# Altium schematic sheet 4_Sensing_I.SchDoc
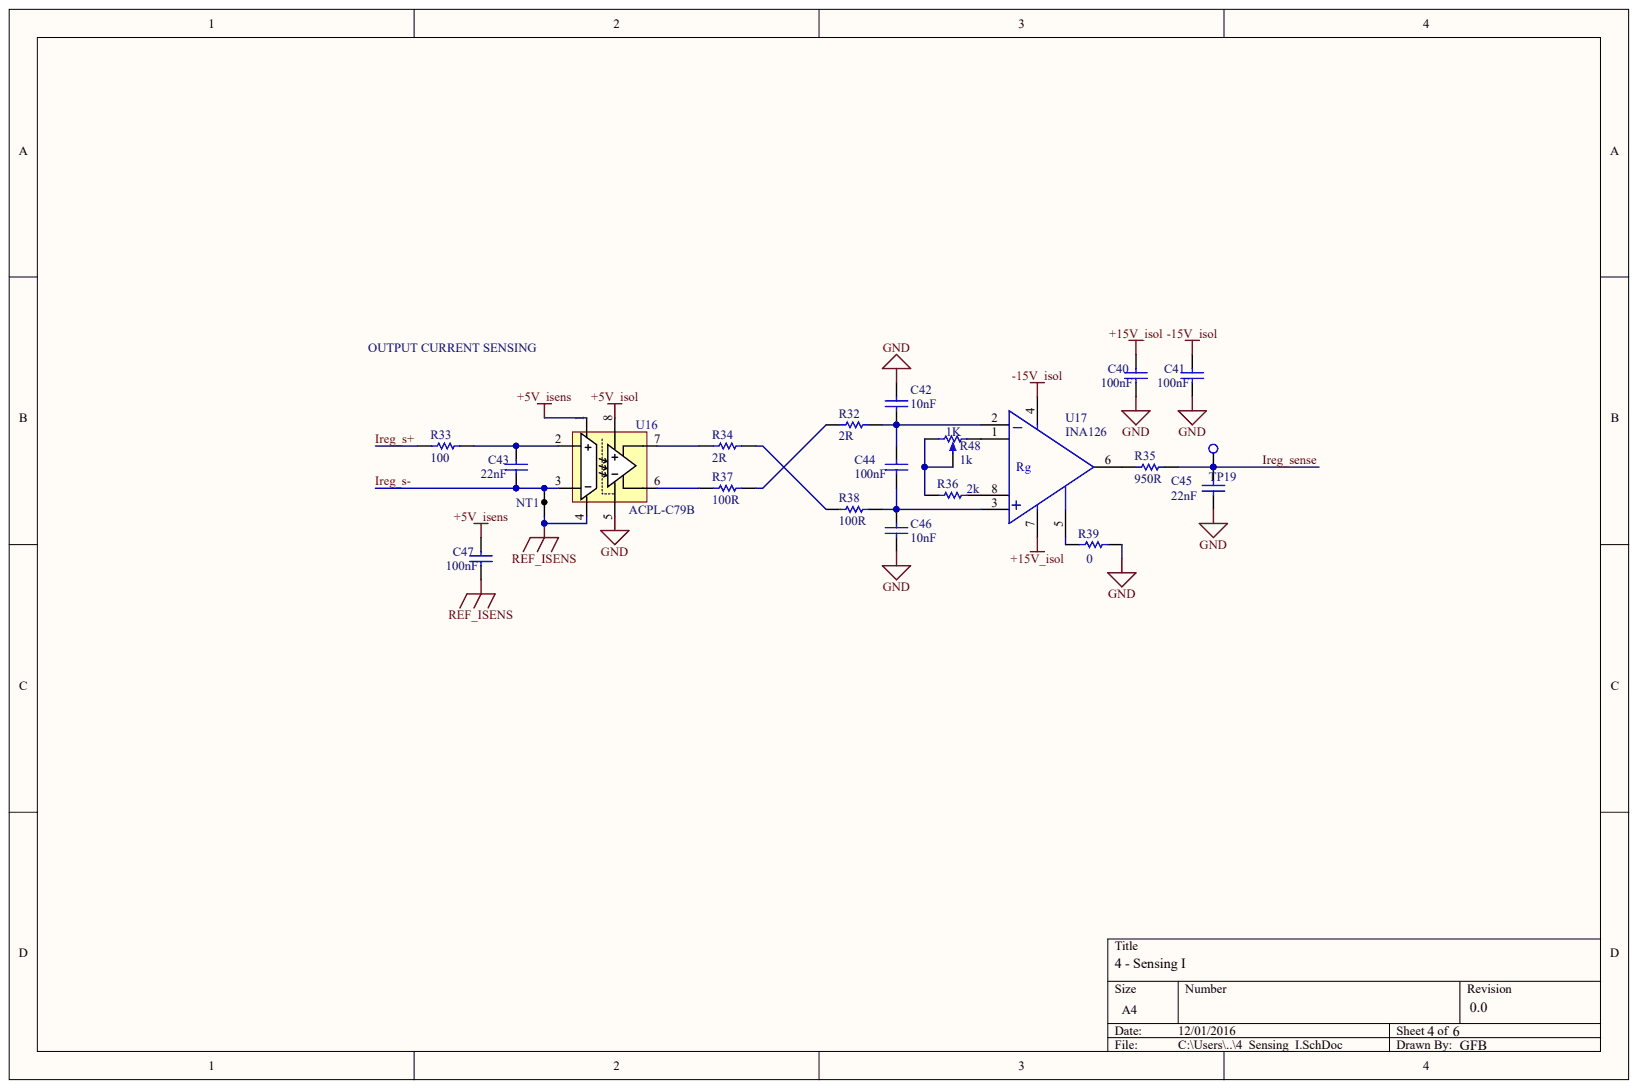
[diagram p0: 4_Sensing_I.SchDoc]

## Parts
C40  value 100nF  (hidden comment: Cap)
C41  value 100nF  (hidden comment: Cap)
C42  value 10nF  (hidden comment: Cap)
C43  value 22nF  (hidden comment: Cap)
C44  value 100nF  (hidden comment: Cap)
C45  value 22nF  (hidden comment: Cap)
C46  value 10nF  (hidden comment: Cap)
C47  value 100nF  (hidden comment: Cap)
NT1  NT
R32  value 2R  (hidden comment: Res1)
R33  value 100  (hidden comment: Res1)
R34  value 2R  (hidden comment: Res1)
R35  value 950R  (hidden comment: Res1)
R36  value 2k  (hidden comment: Res1)
R37  value 100R  (hidden comment: Res1)
R38  value 100R  (hidden comment: Res1)
R39  value 0
R48  1k
TP19  TestPoint  (value not drawn)
U16  ACPL-C79B
U17  INA126

## Nets
+5V_ISENS: C47.2 U16.1
+5V_ISOL: U16.8
+15V_ISOL: C40.2 U17.7
-15V_ISOL: C41.2 U17.4
GND: C40.1 C41.1 C42.2 C45.1 C46.1 R39.2 U16.5
REF_ISENS: C47.1 NT1.1 U16.4
IREG_S+: R33.2
IREG_S-: C43.1 NT1.2 U16.3
IREG_SENSE: C45.2 R35.1 TP19.1
NetC42_1: C42.1 C44.2 R32.1 U17.2
NetC43_2: C43.2 R33.1 U16.2
NetC44_1: C44.1 C46.2 R38.1 U17.3
NetR32_2: R32.2 R37.1
NetR34_1: R34.1 R38.2
NetR34_2: R34.2 U16.7
NetR35_2: R35.2 U17.6
NetR36_1: R36.1 U17.8
NetR36_2: R36.2 R48.1 R48.3
NetR37_2: R37.2 U16.6
NetR39_1: R39.1 U17.5
NetR48_2: R48.2 U17.1

## Library data of the parts
R32-R39 = (no symbol name): Power: 5W; Published: 8-Jun-2000; LatestRevisionDate: 17-Jul-2002; LatestRevisionNote: Re-released for DXP Platform.; PackageReference: AXIAL-0.3; PackageDescription: Axial Device, Thru-Hole; 2 Leads; 0.3 in Pin Spacing; ClassName: Iout_sensing; Publisher: Altium Limited
R48 = (no symbol name): Sim Note: Enter the Resistance value and the set point into parameter fields.; Set Position: 0.5; Published: 8-Jun-2000; LatestRevisionDate: 17-Jul-2002; LatestRevisionNote: Re-released for DXP Platform.; PackageReference: VR5; PackageDescription: Variable Resistor, Thru-Hole; 3 Leads; Value: 1K; Publisher: Altium Limited
TP19 = (no symbol name): RS: 262-2185; ClassName: Iout_sensing
U17 = (no symbol name): Manufacturer: Texas Instruments; Manufacturer Part Number: INA126EA/250; Amplifier Type: Instrumentation; Number of Circuits: 1; Slew Rate: 0.4 V/µs; -3db Bandwidth: 200kHz; Current - Input Bias: 10nA; Voltage - Input Offset: 150µV; Current - Supply: 175µA; Current - Output / Channel: 10mA; Voltage - Supply, Single/Dual (±): 2.7 V ~ 36 V, ±1.35 V ~ 18 V; Supplier 1: Digi-Key; RoHS: RoHS Compliant; Category: Integrated Circuits (ICs); Stock 1: 1250; Pricing 1: 250=2.14448, 500=1.92422 (EUR); Packaging: Tape & Reel (TR); Output Type: -; Gain Bandwidth Product: -; Operating Temperature: -40°C ~ 85°C; Mounting Type: Surface Mount; Package / Case: 8-TSSOP, 8-MSOP (0.118", 3.00mm Width); Supplier Device Package: 8-VSSOP; ComponentLink1URL: http://www.ti.com/lit/ds/symlink/ina126.pdf; ComponentLink1Description: http://www.ti.com/lit/ds/symlink/ina126.pdf; Supplier Part Number 1: INA126EATR-ND; ClassName: Iout_sensing; HelpURL: C:\Users\Gonzalo\GoogleDrive\UC3M\Flyback_board\3_datasheets\opamp_ina126.pdf
C40-C47 NT1 U16 = (no symbol name): ClassName: Iout_sensing
  C40-C47: Published: 8-Jun-2000; LatestRevisionDate: 17-Jul-2002; LatestRevisionNote: Re-released for DXP Platform.; PackageReference: RAD-0.3; PackageDescription: Radial Cap, Thru-Hole; 2 Leads; 0.3 in Pin Spacing; Publisher: Altium Limited
  U16: HelpURL: C:\Users\Gonzalo\GoogleDrive\UC3M\Flyback_board\3_datasheets\isol_ACPL-C79x_2014-08-28.pdf
